# Altium schematic sheet AuxSupply_USB.SchDoc
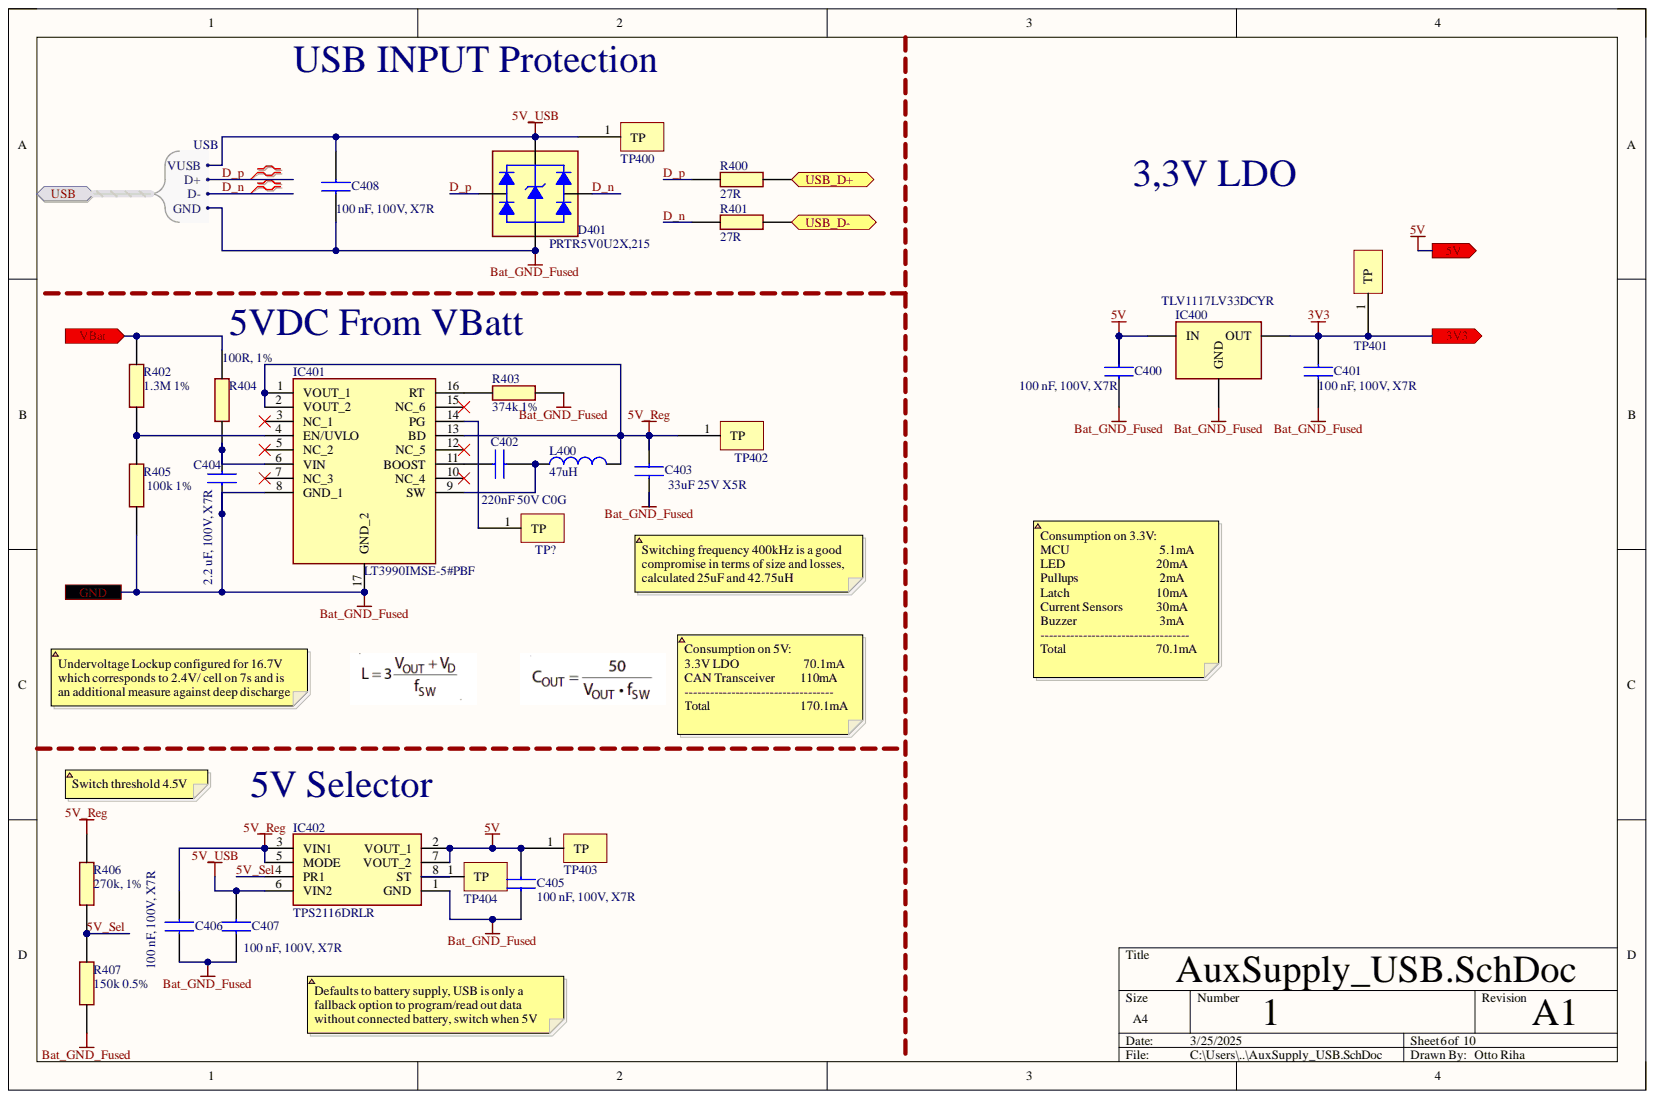
[diagram p0: AuxSupply_USB.SchDoc]

## Parts
C400  100 nF, 100V, X7R  [MAJx16]
C401  100 nF, 100V, X7R  [MAJx16]
C402  220nF 50V C0G  value 220nF 50V  [CAPC3216X180N]
C403  33uF 25V X5R  [CAPC3216X180N]
C404  2.2 uF, 100V, X7R  [CAPC2012X145N]
C405  100 nF, 100V, X7R  [MAJx16]
C406  100 nF, 100V, X7R  [MAJx16]
C407  100 nF, 100V, X7R  [MAJx16]
C408  100 nF, 100V, X7R  [MAJx16]
D401  PRTR5V0U2X,215  [PRTR5V0U2X]
IC400  TLV1117LV33DCYR  [SOT230P700X180-4N]
IC401  LT3990IMSE-5#PBF  [SOP50P490X110-17N]
IC402  TPS2116DRLR  [SOTFL50P160X60-8N]
L400  47uH  [CLF6045_*]
R400  value 27R  [RESC1608X55N]  (hidden comment: 27R 5%)
R401  value 27R  [RESC1608X55N]  (hidden comment: 27R 5%)
R402  1.3M 1%  value 1.3M  [RESC1608X55N]
R403  value 374k 1%  [RESC1608X55N]  (hidden comment: RMCF0603FT374K)
R404  100R, 1%  [RESC1608X50N]
R405  100k 1%  value 100k  [RESC1608X55N]
R406  270k, 1%  [RESC1608X55N]
R407  150k 0.5%  [ERA3AEB101V]
TP400  Test Pad 1mm  [Test Pad 1mm-Footprint-1]  (value not drawn)
TP401  Test Pad 1mm  [Test Pad 1mm-Footprint-1]  (value not drawn)
TP402  Test Pad 1mm  [Test Pad 1mm-Footprint-1]  (value not drawn)
TP403  Test Pad 1mm  [Test Pad 1mm-Footprint-1]  (value not drawn)
TP404  Test Pad 1mm  [Test Pad 1mm-Footprint-1]  (value not drawn)
TP?  Test Pad 1mm  [Test Pad 1mm-Footprint-1]  (value not drawn)

## Ports
3V3, 5V, GND, USB, USB.D+, USB.D-, USB.GND, USB.VUSB, USB_D+, USB_D-, VBat

## Nets
5V_Reg: C403.2 C406.2 IC401.1(VOUT_1) IC401.2(VOUT_2) IC401.13(BD) IC402.3(VIN1) IC402.5(MODE) L400.2 R406.2 TP402.1
3V3: C401.2 IC400.2 TP401.1 ; port 3V3
5V: C400.2 C405.2 IC400.3 IC402.2(VOUT_1) IC402.7(VOUT_2) TP403.1 ; port 5V
5V_Sel: IC402.4(PR1) R406.1 R407.2
5V_USB: C407.2 C408.2 D401.4 IC402.6(VIN2) TP400.1 ; port USB
Bat_GND_Fused: C400.1 C401.1 C403.1 C404.1 C405.1 C406.1 C407.1 C408.1 D401.1 IC400.1 IC401.8(GND_1) IC401.17(GND_2) IC402.1(GND) R403.1 R405.1 R407.1 ; port GND, port USB
D_n: D401.3 R401.1 ; port USB
D_p: D401.2 R400.1 ; port USB
VBat: R402.2 R404.2 ; port VBat
NetC402_1: C402.1 IC401.11(BOOST)
NetC402_2: C402.2 IC401.9(SW) L400.1
NetC404_2: C404.2 IC401.6(VIN) R404.1
NetIC401_3: IC401.3(NC_1)
NetIC401_4: IC401.4(EN/UVLO) R402.1 R405.2
NetIC401_5: IC401.5(NC_2)
NetIC401_7: IC401.7(NC_3)
NetIC401_10: IC401.10(NC_4)
NetIC401_12: IC401.12(NC_5)
NetIC401_14: IC401.14(PG) TP?.1
NetIC401_15: IC401.15(NC_6)
NetIC401_16: IC401.16(RT) R403.2
NetIC402_8: IC402.8(ST) TP404.1
NetR400_2: R400.2
NetR401_2: R401.2

## Library data of the parts
C400 C401 C405-C408 = MAJCH168BB7104KTEA01: Capacitor 100nF, 100V, X7R; Manufacturer_Name: TAIYO YUDEN; Arrow Part Number: MAJCH168BB7104KTEA01; Arrow Price/Stock: https://www.arrow.com/en/products/majch168bb7104ktea01/taiyo-yuden?utm_currency=USD&region=nac; Component Kind: Standard; Datasheet Link: https://ds.yuden.co.jp/TYCOMPAS/or/detail?pn=MAJCH168BB7104KTEA01; Height: 0.8mm; Library Name: SamacSys.SchLib; Manufacturer_Part_Number: MAJCH168BB7104KTEA01; Mouser Part Number: 963-MAJCH168BB7104KT; Mouser Price/Stock: https://www.mouser.co.uk/ProductDetail/TAIYO-YUDEN/MAJCH168BB7104KTEA01?qs=ZcfC38r4PovaTBrG0IdezQ%3D%3D; Supplier 1: Mouser; Supplier Part Number 1: 963-MAJCH168BB7104KT
C402 = GRM31C5C1H224JE02L: Capacitor 220nF 50V C0G; Manufacturer_Name: Murata Electronics; Arrow Part Number: GRM31C5C1H224JE02L; Arrow Price/Stock: https://www.arrow.com/en/products/grm31c5c1h224je02l/murata-manufacturing?region=nac; Component Kind: Standard; Datasheet Link: https://search.murata.co.jp/Ceramy/image/img/A01X/G101/ENG/GRM31C5C1H224JE02-01A.pdf; Height: 1.8mm; Library Name: SamacSys.SchLib; Manufacturer_Part_Number: GRM31C5C1H224JE02L; Mouser Part Number: 81-GRM31C5C1H224JE2L; Mouser Price/Stock: https://www.mouser.co.uk/ProductDetail/Murata-Electronics/GRM31C5C1H224JE02L?qs=qSfuJ%252Bfl%2Fd7ovrk3%2F2FSbQ%3D%3D; Value: 220nF 50V
C403 = C3216X5R1E336M160AC: MULTILAYER CERAMIC CHIP CAPACITORS; Manufacturer_Name: TDK; Arrow Part Number: C3216X5R1E336M160AC; Arrow Price/Stock: https://www.arrow.com/en/products/c3216x5r1e336m160ac/tdk; Component Kind: Standard; Datasheet Link: https://product.tdk.com/info/en/catalog/datasheets/mlcc_commercial_midvoltage_en.pdf; Height: 1.8mm; Library Name: SamacSys.SchLib; Manufacturer_Part_Number: C3216X5R1E336M160AC; Mouser Part Number: 810-C3216X5R1E336M; Mouser Price/Stock: https://www.mouser.co.uk/ProductDetail/TDK/C3216X5R1E336M160AC?qs=LcTL%2F5vFEzFIMvFz4LzwTw%3D%3D
C404 = C2012X7R2A225K125AC: Capacitor 2.2 uF, 100V, X7R; Manufacturer_Name: TDK; Arrow Part Number: C2012X7R2A225K125AC; Arrow Price/Stock: https://www.arrow.com/en/products/c2012x7r2a225k125ac/tdk?utm_currency=USD&region=nac; Component Kind: Standard; Datasheet Link: https://product.tdk.com/info/en/catalog/datasheets/mlcc_commercial_midvoltage_en.pdf; Height: 1.45mm; Library Name: SamacSys.SchLib; Manufacturer_Part_Number: C2012X7R2A225K125AC; Mouser Part Number: 810-C2012X7R2225K125; Mouser Price/Stock: https://www.mouser.co.uk/ProductDetail/TDK/C2012X7R2A225K125AC?qs=17ckDYBRdekDf%2FwiwHq7Mg%3D%3D; Supplier 1: Mouser; Supplier Part Number 1: 810-C2012X7R2225K125
D401 = PRTR5V0U2X,215: Diode; Manufacturer_Name: Nexperia; Arrow Part Number: PRTR5V0U2X,215; Arrow Price/Stock: https://www.arrow.com/en/products/prtr5v0u2x215/nexperia?utm_currency=USD&region=europe; Component Kind: Standard; Datasheet Link: https://assets.nexperia.com/documents/data-sheet/PRTR5V0U2X.pdf; Height: 1.1mm; Library Name: SamacSys.SchLib; Manufacturer_Part_Number: PRTR5V0U2X,215; Mouser Part Number: 771-PRTR5V0U2X-T/R; Mouser Price/Stock: https://www.mouser.co.uk/ProductDetail/Nexperia/PRTR5V0U2X215?qs=LOCUfHb8d9sDkgY4cRj8Lw%3D%3D
IC400 = TLV1117LV33DCYR: 1-A; Manufacturer_Name: Texas Instruments; Arrow Part Number: TLV1117LV33DCYR; Arrow Price/Stock: https://www.arrow.com/en/products/tlv1117lv33dcyr/texas-instruments?utm_currency=USD&region=nac; Component Kind: Standard; Datasheet Link: http://www.ti.com/lit/gpn/tlv1117lv; Height: 1.8mm; Library Name: SamacSys.SchLib; Manufacturer_Part_Number: TLV1117LV33DCYR; Mouser Part Number: 595-TLV1117LV33DCYR; Mouser Price/Stock: https://www.mouser.co.uk/ProductDetail/Texas-Instruments/TLV1117LV33DCYR?qs=tEz3BkPb1rwzXMb8FiWhow%3D%3D
IC401 = LT3990IMSE-5#PBF: Integrated Circuit; Manufacturer_Name: Analog Devices; Arrow Part Number: LT3990IMSE-5#PBF; Arrow Price/Stock: https://www.arrow.com/en/products/lt3990imse-5pbf/analog-devices?region=nac; Component Kind: Standard; Datasheet Link: https://www.analog.com/LT3990/datasheet; Height: 1.1mm; Library Name: SamacSys.SchLib; Manufacturer_Part_Number: LT3990IMSE-5#PBF; Mouser Part Number: 584-LT3990IMSE-5#PBF; Mouser Price/Stock: https://www.mouser.co.uk/ProductDetail/Analog-Devices/LT3990IMSE-5PBF?qs=hVkxg5c3xu%252BVMOa5O8HlFw%3D%3D
IC402 = TPS2116DRLR: Integrated Circuit; Manufacturer_Name: Texas Instruments; Arrow Part Number: TPS2116DRLR; Arrow Price/Stock: https://www.arrow.com/en/products/tps2116drlr/texas-instruments?utm_currency=USD&region=nac; Component Kind: Standard; Datasheet Link: https://www.ti.com/lit/gpn/tps2116; Height: 0.6mm; Library Name: SamacSys.SchLib; Manufacturer_Part_Number: TPS2116DRLR; Mouser Part Number: 595-TPS2116DRLR; Mouser Price/Stock: https://www.mouser.co.uk/ProductDetail/Texas-Instruments/TPS2116DRLR?qs=aP1CjGhiNiGo3XAyXcVPaQ%3D%3D
L400 = CLF6045NIT-470M-D: Inductor; Manufacturer_Name: TDK; Arrow Part Number: CLF6045NIT-470M-D; Arrow Price/Stock: https://www.arrow.com/en/products/clf6045nit-470m-d/tdk; Component Kind: Standard; Datasheet Link: https://product.tdk.com/system/files/dam/doc/product/inductor/inductor/smd/catalog/inductor_automotive_power_clf6045ni-d_en.pdf; Library Name: SamacSys.SchLib; Manufacturer_Part_Number: CLF6045NIT-470M-D; Mouser Part Number: 810-CLF6045NIT470MD; Mouser Price/Stock: https://www.mouser.co.uk/ProductDetail/TDK/CLF6045NIT-470M-D?qs=YdQ7Kj7W0bxoCM5zjdDu7Q%3D%3D
R400 R401 = RK73B1JTTD270J: Resistor 27R 5%; Manufacturer_Name: KOA Speer; Arrow Part Number: RK73B1JTTD270J; Arrow Price/Stock: https://www.arrow.com/en/products/rk73b1jttd270j/koa-speer-electronics?region=nac; Component Kind: Standard; Datasheet Link: http://www.koaspeer.com/catimages/Products/RK73-RT/RK73-RT.pdf; Height: 0.55mm; Library Name: SamacSys.SchLib; Manufacturer_Part_Number: RK73B1JTTD270J; Mouser Part Number: 660-RK73B1JTTD270J; Mouser Price/Stock: https://www.mouser.co.uk/ProductDetail/KOA-Speer/RK73B1JTTD270J?qs=Ng4KxyU0dfwqvzJSFEpRVQ%3D%3D; Supplier 1: Mouser; Supplier Part Number 1: 660-RK73B1JTTD270J
  R400: Value: 27R
R402 = MCT0603MD1304BP500: Resistor 1.3M 1%; Manufacturer_Name: Vishay; Component Kind: Standard; Datasheet Link: http://www.vishay.com/docs/28705/mcx0x0xpro.pdf; Height: 0.55mm; Library Name: SamacSys.SchLib; Manufacturer_Part_Number: MCT0603MD1304BP500; Mouser Part Number: 594-MCT0603MD1304BP5; Mouser Price/Stock: https://www.mouser.co.uk/ProductDetail/Vishay/MCT0603MD1304BP500?qs=Jslch3jnSjn3NEL17BAJuQ%3D%3D; Supplier 1: Mouser; Supplier Part Number 1: 594-MCT0603MD1304BP5
R403 = RMCF0603FT374K: Resistor 374k 1%; Manufacturer_Name: Stackpole Electronics; Component Kind: Standard; Datasheet Link: https://www.seielect.com/Catalog/SEI-RMCF_RMCP.pdf; Height: 0.55mm; Library Name: SamacSys.SchLib; Manufacturer_Part_Number: RMCF0603FT374K; Supplier 1: Avnet; Supplier Part Number 1: RMCF0603FT374K
R404 = CRCW0603100RFKEA: Resistor 100R, 1%; Manufacturer_Name: Vishay; Arrow Part Number: CRCW0603100RFKEA; Arrow Price/Stock: https://www.arrow.com/en/products/crcw0603100rfkea/vishay?utm_currency=USD&region=europe; Component Kind: Standard; Datasheet Link: http://www.vishay.com/docs/20035/dcrcwe3.pdf; Height: 0.5mm; Library Name: SamacSys.SchLib; Manufacturer_Part_Number: CRCW0603100RFKEA; Mouser Part Number: 71-CRCW0603-100-E3; Mouser Price/Stock: https://www.mouser.co.uk/ProductDetail/Vishay-Dale/CRCW0603100RFKEA?qs=90AHS1y%2FsidGWjjxZAupKg%3D%3D; Supplier 1: Mouser; Supplier Part Number 1: 71-CRCW0603-100-E3; Value: 100R
R405 = CRCW0603100KFKEAC: Resistor 100k, 1%; Manufacturer_Name: Vishay; Arrow Part Number: CRCW0603100KFKEAC; Arrow Price/Stock: https://www.arrow.com/en/products/crcw0603100kfkeac/vishay?utm_currency=USD&region=nac; Component Kind: Standard; Datasheet Link: https://www.mouser.com/datasheet/2/427/crcwce3-1223726.pdf; Height: 0.55mm; Library Name: SamacSys.SchLib; Manufacturer_Part_Number: CRCW0603100KFKEAC; Mouser Part Number: 71-CRCW0603100KFKEAC; Mouser Price/Stock: https://www.mouser.co.uk/ProductDetail/Vishay-Dale/CRCW0603100KFKEAC?qs=E3Y5ESvWgWPxzfNYyMgX1w%3D%3D; Supplier 1: Mouser; Supplier Part Number 1: 71-CRCW0603100KFKEAC
R406 = CRG0603F270K: Resistor 270k, 1%; Manufacturer_Name: TE Connectivity; Component Kind: Standard; Datasheet Link: http://docs-europe.electrocomponents.com/webdocs/13d1/0900766b813d116f.pdf; Height: 0.55mm; Library Name: SamacSys.SchLib; Manufacturer_Part_Number: CRG0603F270K; Supplier 1: Arrow Electronics; Supplier Part Number 1: 1622896-1
R407 = ERA-3AED154V: Resistor 150k 0.5%; Manufacturer_Name: Panasonic; Arrow Part Number: ERA-3AED154V; Arrow Price/Stock: https://www.arrow.com/en/products/era-3aed154v/panasonic?region=nac; Component Kind: Standard; Datasheet Link: https://industrial.panasonic.com/cdbs/www-data/pdf/RDM0000/AOA0000C307.pdf; Height: 0.55mm; Library Name: SamacSys.SchLib; Manufacturer_Part_Number: ERA-3AED154V; Mouser Part Number: 667-ERA-3AED154V; Mouser Price/Stock: https://www.mouser.co.uk/ProductDetail/Panasonic/ERA-3AED154V?qs=MNPzkKEzRtSm98HasUBZjQ%3D%3D; Supplier 1: Mouser; Supplier Part Number 1: 667-ERA-3AED154V
TP400-TP404 TP? = CMP-002-00031-3: 1mm radius circuilar test pad; Component Kind: Standard; Library Name: EPFL Racing Team
